# Altium schematic sheet lv_power_diode_laser_cc.SchDoc(lv_power_diode_laser_cc)
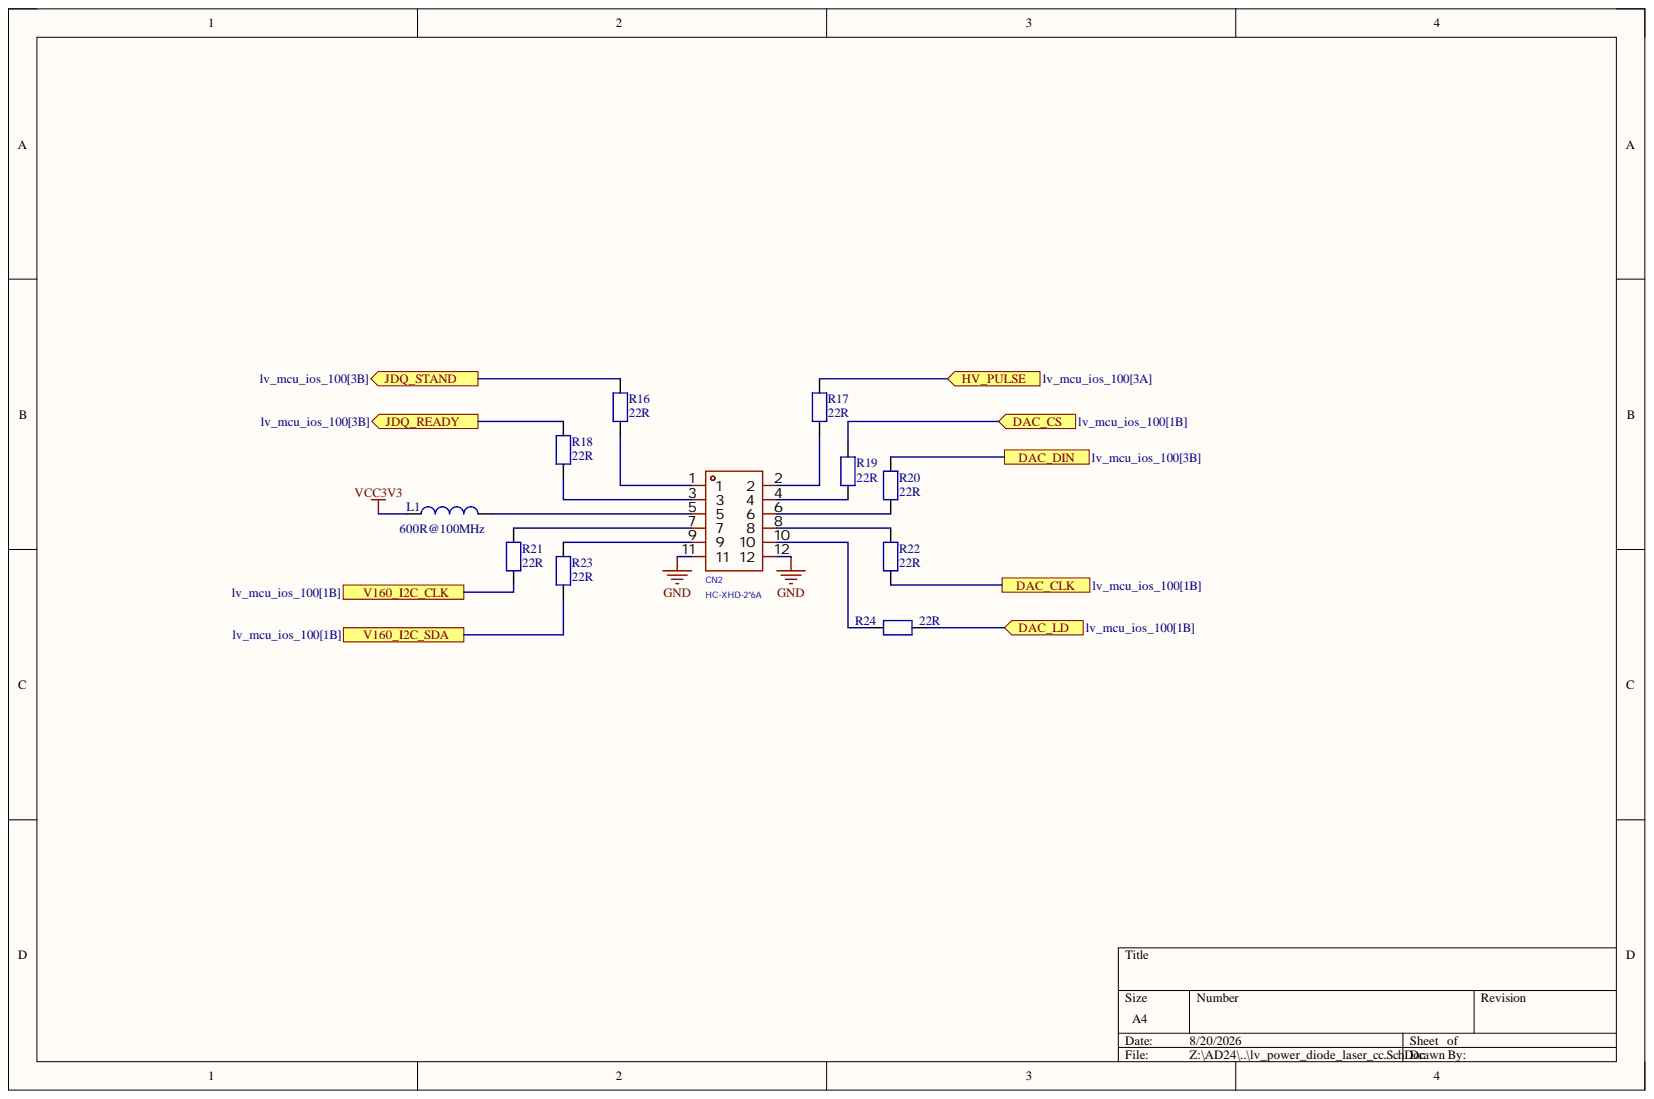
[diagram p0: lv_power_diode_laser_cc.SchDoc]

## Parts
CN2  HC-XHD-2*6A  [CONN-TH_12P-P2.50_HCTL_HC-XHD-2X6A]
L1  600R@100MHz  [L0603]
R16  22R  [R0402]
R17  22R  [R0402]
R18  22R  [R0402]
R19  22R  [R0402]
R20  22R  [R0402]
R21  22R  [R0402]
R22  22R  [R0402]
R23  22R  [R0402]
R24  22R  [R0402]

## Ports
DAC_CLK, DAC_CS, DAC_DIN, DAC_LD, HV_PULSE, JDQ_READY, JDQ_STAND, V160_I2C_CLK, V160_I2C_SDA

## Nets
GND: CN2.11 CN2.12
VCC3V3: L1.1
DAC_CLK: R22.2 ; port DAC_CLK
DAC_CS: R19.2 ; port DAC_CS
DAC_DIN: R20.2 ; port DAC_DIN
DAC_LD: R24.2 ; port DAC_LD
HV_PULSE: R17.2 ; port HV_PULSE
JDQ_READY: R18.1 ; port JDQ_READY
JDQ_STAND: R16.1 ; port JDQ_STAND
V160_I2C_CLK: R21.1 ; port V160_I2C_CLK
V160_I2C_SDA: R23.1 ; port V160_I2C_SDA
NetCN2_1: CN2.1 R16.2
NetCN2_2: CN2.2 R17.1
NetCN2_3: CN2.3 R18.2
NetCN2_4: CN2.4 R19.1
NetCN2_5: CN2.5 L1.2
NetCN2_6: CN2.6 R20.1
NetCN2_7: CN2.7 R21.2
NetCN2_8: CN2.8 R22.1
NetCN2_9: CN2.9 R23.2
NetCN2_10: CN2.10 R24.1

## Library data of the parts
CN2 = HC-XHD-2*6A: 插针结构2x6P，间距2.5mm，安装方式直插，参考系列DF1B; Manufacturer: HCTL(华灿天禄); Add into BOM: yes; Current Rating: 3A; Number of PINs Per Row: 6; Pins Structure: 2x6P; Pitch: 2.5mm; Plastic Material: PA66; Reference Series: DF1B; Voltage Rating: 250V; X-Length of Bottom Edge on Board (Spacing Line): 17.5mm; Y-Width of Bottom Edge on Board: 8.6mm; Z-Height of the Board: 9.5mm; Color: -; Component Kind: Standard; Contact Material: 黄铜; Contact Plating: 锡; Convert to PCB: yes; Datasheet: https://item.szlcsc.com/datasheet/HC-XHD-2*6A/3039334.html; Device: HC-XHD-2*6A; Flame Retardant Rating: -; JLCPCB Part Class: Extended Part; LCSC Part Name: 2x6P 间距:2.5mm 直插 系列:DF1B; Library Name: HiPin_Library_AD.IntLib; Manufacturer Part: HC-XHD-2*6A; Mounting Type: 直插; Number of Pins: 12P; Number of Rows: 2; Operating Temperature: -25℃~+85℃; PCB3D: CONN-TH_12P-P2.50_HCTL_HC-XHD-2X6A; Row Spacing: 2.5mm; Supplementary Features: -; Supplier: LCSC; Supplier Footprint: 插件,P=2.5mm; Supplier Part: C2845653; Symbol: HC-XHD-2*6A
L1 = MCD-00047: +/-25%, 350mA, 500mohm DC; Manufacturer: TAI-TECH; Manufacturer_Part_Number: FCM1608KF-601T03; Database Table Name: MCD_PARTS$; Component Kind: Standard; ComponentLink1Description: Datasheet; ComponentLink1URL: http://tunmcdsvn.tun.st.com/Component_Datasheet_Library/Trunk/Inductors/TaiTech_Bead_FCM1608_series; Library Name: MCD_PARTS_database.DbLib
R16-R24 = RES: 1%; Value: 10K; Component Kind: Standard; Library Name: HiPin_Library_AD.IntLib
